# Altium schematic sheet LIDO-HW.200.MCU_Peripherals_2.SchDoc(LIDO-HW.200.MCU_Peripherals_2)
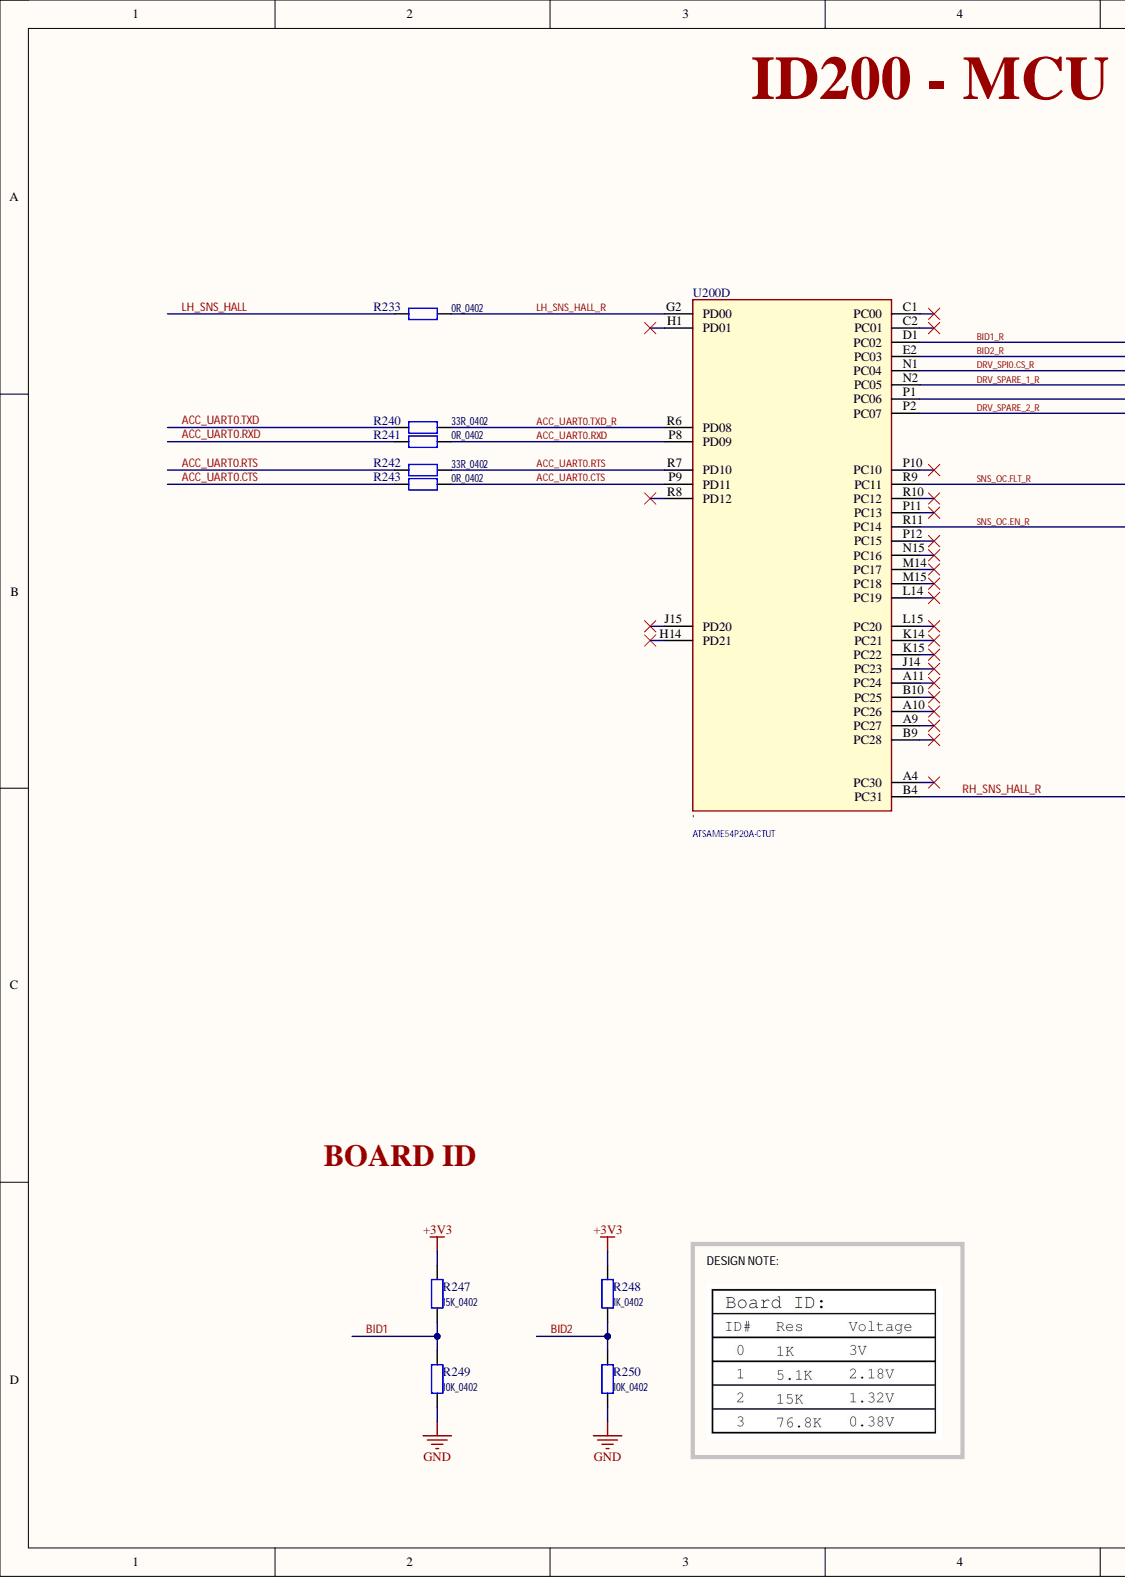
[diagram p0: LIDO-HW.200.MCU_Peripherals_2.SchDoc, left]
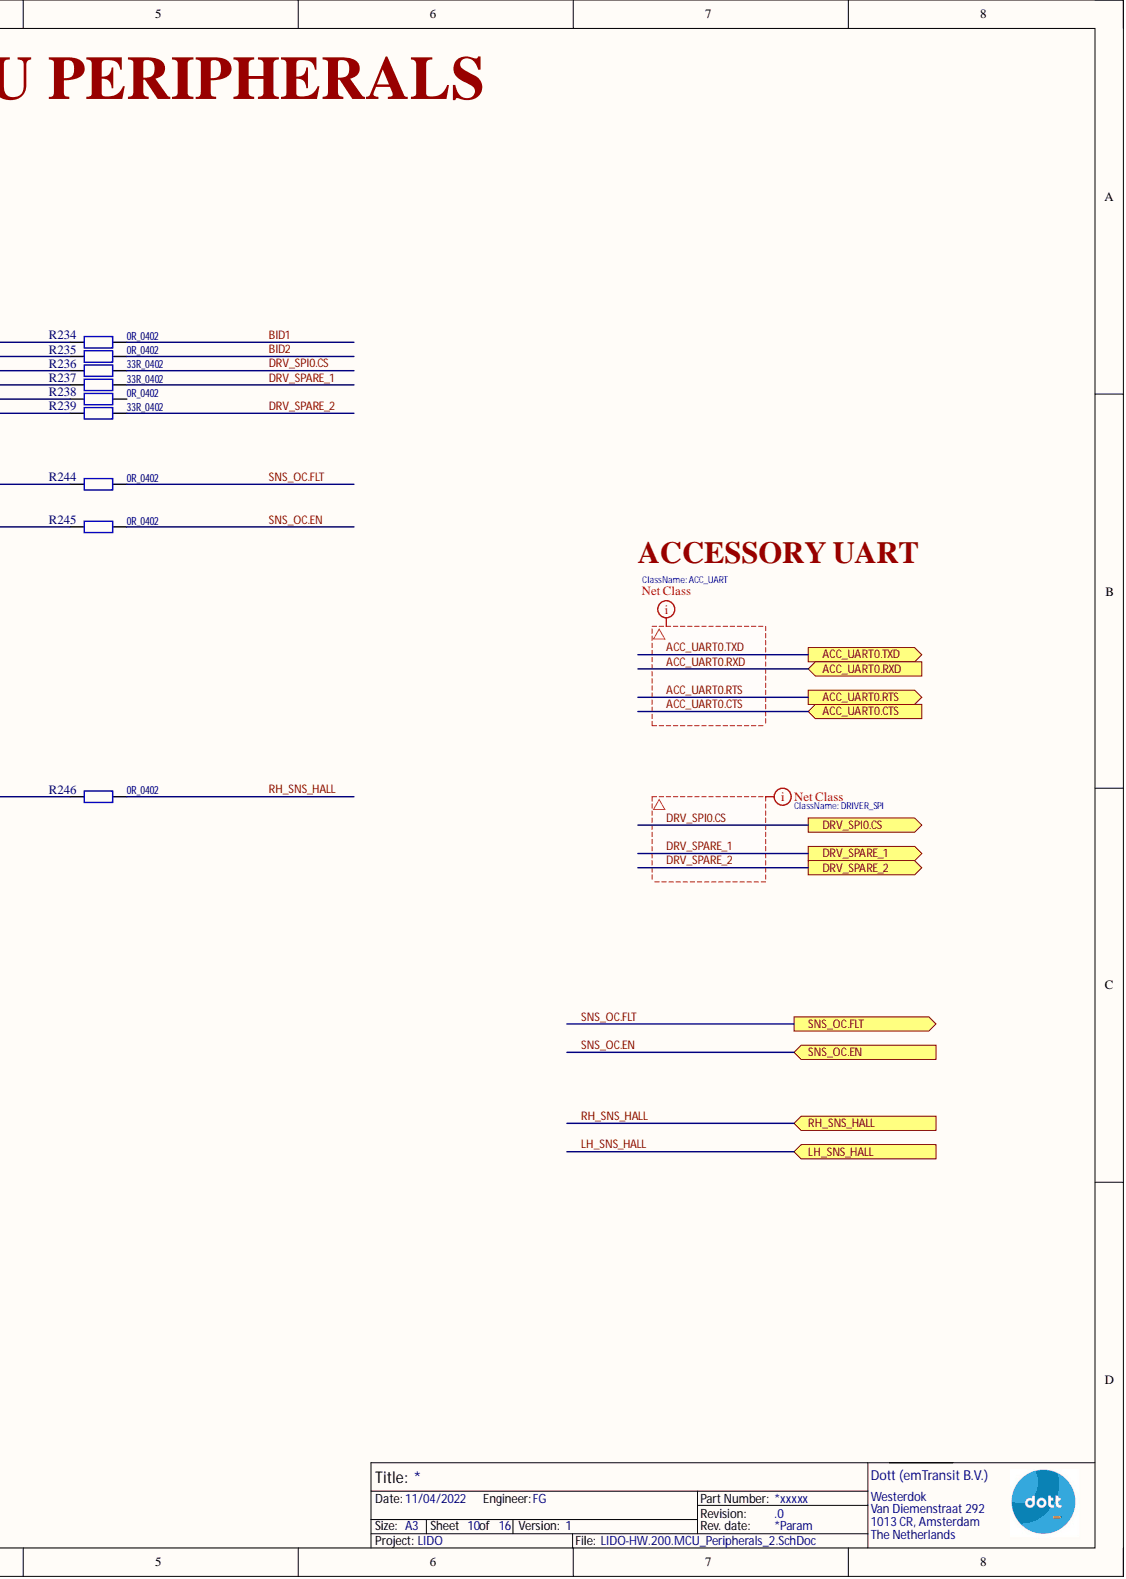
[diagram p1: LIDO-HW.200.MCU_Peripherals_2.SchDoc, right]

## Parts
R233  0R_0402
R234  0R_0402
R235  0R_0402
R236  33R_0402
R237  33R_0402
R238  0R_0402
R239  33R_0402
R240  33R_0402
R241  0R_0402
R242  33R_0402
R243  0R_0402
R244  0R_0402
R245  0R_0402
R246  0R_0402
R247  15K_0402
R248  1K_0402
R249  10K_0402
R250  10K_0402
U200  '  (part D)

## Ports
ACC_UART0.CTS, ACC_UART0.RTS, ACC_UART0.RXD, ACC_UART0.TXD, DRV_SPARE_1, DRV_SPARE_2, DRV_SPI0.CS, LH_SNS_HALL, RH_SNS_HALL, SNS_OC.EN, SNS_OC.FLT

## Nets
+3V3: R247.2 R248.2
GND: R249.1 R250.1
ACC_UART0.CTS: R243.1 R243.2 U200.P9(PD11) ; port ACC_UART0.CTS
ACC_UART0.RTS: R242.1 R242.2 U200.R7(PD10) ; port ACC_UART0.RTS
ACC_UART0.RXD: R241.1 R241.2 U200.P8(PD09) ; port ACC_UART0.RXD
ACC_UART0.TXD: R240.2 ; port ACC_UART0.TXD
ACC_UART0.TXD_R: R240.1 U200.R6(PD08)
BID1: R234.1 R247.1 R249.2
BID1_R: R234.2 U200.D1(PC02)
BID2: R235.1 R248.1 R250.2
BID2_R: R235.2 U200.E2(PC03)
DRV_SPARE_1: R237.1 ; port DRV_SPARE_1
DRV_SPARE_1_R: R237.2 U200.N2(PC05)
DRV_SPARE_2: R239.1 ; port DRV_SPARE_2
DRV_SPARE_2_R: R239.2 U200.P2(PC07)
DRV_SPI0.CS: R236.1 ; port DRV_SPI0.CS
DRV_SPI0.CS_R: R236.2 U200.N1(PC04)
LH_SNS_HALL: R233.2 ; port LH_SNS_HALL
LH_SNS_HALL_R: R233.1 U200.G2(PD00)
RH_SNS_HALL: R246.1 ; port RH_SNS_HALL
RH_SNS_HALL_R: R246.2 U200.B4(PC31)
SNS_OC.EN: R245.1 ; port SNS_OC.EN
SNS_OC.EN_R: R245.2 U200.R11(PC14)
SNS_OC.FLT: R244.1 ; port SNS_OC.FLT
SNS_OC.FLT_R: R244.2 U200.R9(PC11)
NetR238_2: R238.2 U200.P1(PC06)

Not connected: R238 1; U200 A4(PC30) A9(PC27) A10(PC26) A11(PC24) B9(PC28) B10(PC25) C1(PC00) C2(PC01) H1(PD01) H14(PD21) J14(PC23) J15(PD20) K14(PC21) K15(PC22) L14(PC19) L15(PC20) M14(PC17) M15(PC18) N15(PC16) P10(PC10) P11(PC13) P12(PC15) R8(PD12) R10(PC12)

## Library data of the parts
R233-R250 = Resistor: Resistor Symbol; Component Kind: Standard; Library Name: 02_Resistors.SchLib
U200 = ATMEL_ATSAME54P20A-CT_A: 32-bit ARM® Cortex®-M4F MCUs with 1 Msps 12-bit ADC,  QSPI, USB, Ethernet, and PTC; Component Kind: Standard; Library Name: LIDO_Lib.SchLib
